# Altium schematic sheet Overvoltage_Protection.SchDoc(OVP)
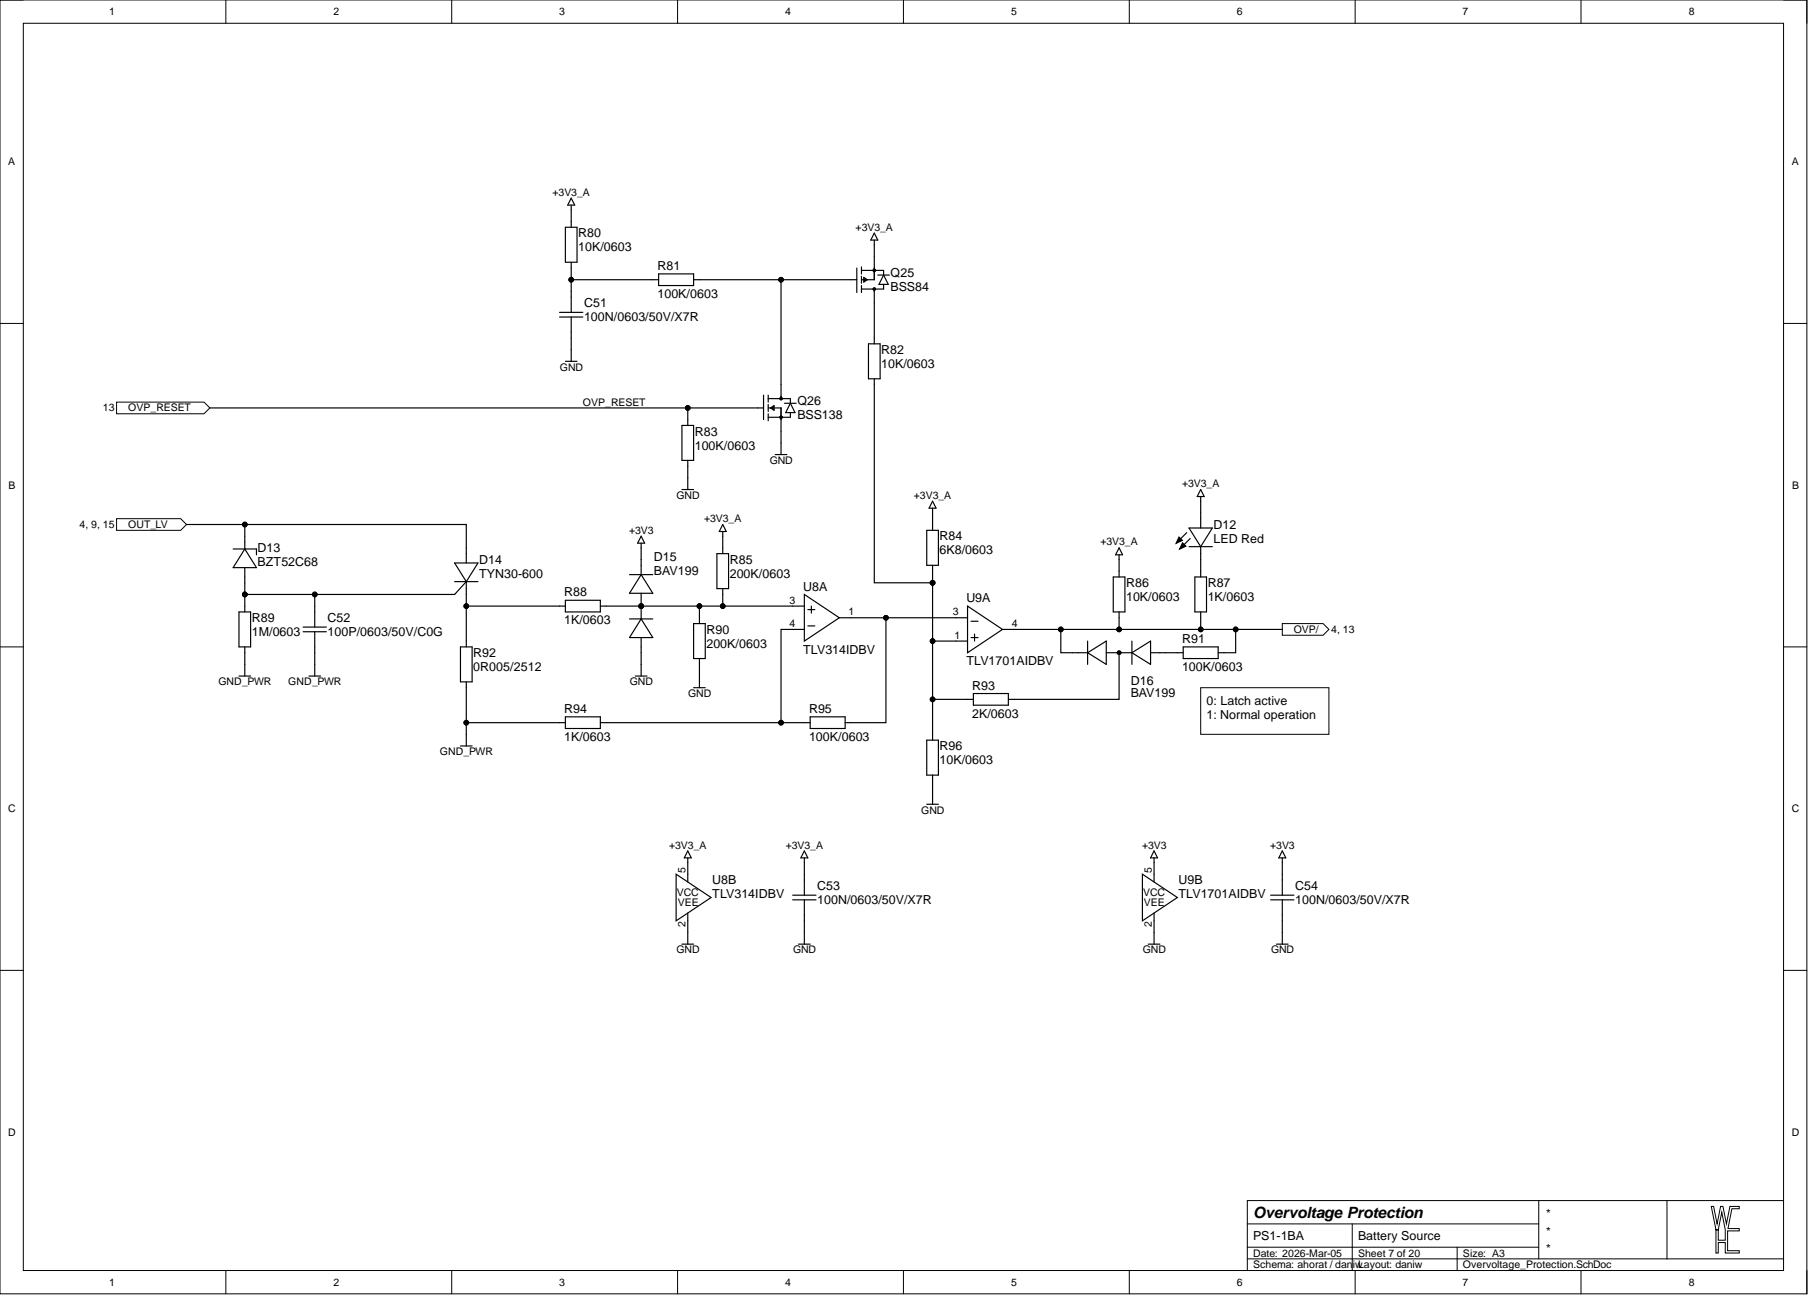
[diagram p0: Overvoltage_Protection.SchDoc]

## Parts
C51  100N/0603/50V/X7R  [CAP0603_X7R]
C52  100P/0603/50V/C0G  [CAP0603_C0G]
C53  100N/0603/50V/X7R  [CAP0603_X7R]
C54  100N/0603/50V/X7R  [CAP0603_X7R]
D12  LED Red  value Red  [LED0805_RED]
D13  BZT52C68  [SOD3718X125]
D14  TYN30-600  [TO220-3]
D15  BAV199  [SOT2395P280X125-3-SE]
D16  BAV199  [SOT2395P280X125-3-SE]
Q25  BSS84  [SOT2395P280X125-3-SE]
Q26  BSS138  [SOT2395P280X125-3-SE]
R80  10K/0603  [R0603-0.40-WW]
R81  100K/0603  [R0603-0.40-WW]
R82  10K/0603  [R0603-0.40-WW]
R83  100K/0603  [R0603-0.40-WW]
R84  6K8/0603  [R0603-0.40-WW]
R85  200K/0603  [R0603-0.40-WW]
R86  10K/0603  [R0603-0.40-WW]
R87  1K/0603  [R0603-0.40-WW]
R88  1K/0603  [R0603-0.40-WW]
R89  1M/0603  [R0603-0.40-WW]
R90  200K/0603  [R0603-0.40-WW]
R91  100K/0603  [R0603-0.40-WW]
R92  0R005/2512  [R2512-0.65-WW]
R93  2K/0603  [R0603-0.40-WW]
R94  1K/0603  [R0603-0.40-WW]
R95  100K/0603  [R0603-0.40-WW]
R96  10K/0603  [R0603-0.40-WW]
U8  TLV314IDBV  [SOT2395P280X125-5-NW]  (parts A,B)
U9  TLV1701AIDBV  [SOT2395P280X125-5-NW]  (parts A,B)

## Ports
OUT_LV, OVP/, OVP_RESET

## Nets
+3V3: C54.1 D15.2 U9.5
+3V3_A: C53.1 D12.2 Q25.2 R80.1 R84.1 R85.1 R86.2 U8.5
GND: C51.2 C53.2 C54.2 D15.1 Q26.2 R83.2 R90.2 R96.2 U8.2 U9.2
GND_PWR: C52.2 R89.2 R92.2 R94.2
OUT_LV: D13.1 D14.2 ; port OUT_LV
OVP/: D16.2 R86.1 R87.1 R91.2 U9.4 ; port OVP/
OVP_RESET: Q26.1 R83.1 ; port OVP_RESET
NetC51_1: C51.1 R80.2 R81.1
NetC52_1: C52.1 D13.2 D14.3 R89.1
NetD12_1: D12.1 R87.2
NetD14_1: D14.1 R88.1 R92.1
NetD15_3: D15.3 R85.2 R88.2 R90.1 U8.3
NetD16_1: D16.1 R91.1
NetD16_3: D16.3 R93.2
NetQ25_1: Q25.1 Q26.3 R81.2
NetQ25_3: Q25.3 R82.1
NetR82_2: R82.2 R84.2 R93.1 R96.1 U9.1
NetR94_1: R94.1 R95.2 U8.4
NetR95_1: R95.1 U8.1 U9.3

## Library data of the parts
C51 C53 C54 = 100N/0603/50V/X7R: CAP SM 0603 100nF 50V X7R; Manufacturer: GENERIC; Manufacturer 1: YAGEO; Manufacturer Part Number 1: CC0603KPX7R9BB104; Absolute Value: 1E-7; Database Table Name: C$; Eurocircuits GPN: GPC0603104; Component Kind: Standard; HelpURL: https://www.yageo.com/upload/media/product/app/datasheet/mlcc/upy-gphc_x7r_6_3v-to-250v.pdf; JLC Type: Basic; Manufacturer 2: YAGEO; Manufacturer Part Number 2: CC0603MRX7R9BB104; Manufacturer Part Number 3: CC0603KRX7R9BB104; Package: 0603; Part Number: 100N/0603/50V/X7R; Supplier 1: Digi-Key; Supplier 2: Digi-Key; Supplier 3: LCSC; Supplier Part Number 1: 311-3567-1-ND; Supplier Part Number 2: 311-4110-1-ND; Supplier Part Number 3: C14663; Value: 100nF; Library Name: daniw_altium_lib.DbLib
C52 = 100P/0603/50V/C0G: CAP SM 0603 100pF 50V C0G; Manufacturer: GENERIC; Manufacturer 1: YAGEO; Manufacturer Part Number 1: CC0603JRNPO9BN101; Absolute Value: 1E-10; Database Table Name: C$; Eurocircuits GPN: GPC0603101-C0G; Component Kind: Standard; HelpURL: https://www.yageo.com/upload/media/product/productsearch/datasheet/mlcc/UPY-GP_NP0_16V-to-50V_18.pdf; JLC Type: Basic; Manufacturer 2: YAGEO; Manufacturer Part Number 2: CC0603KRNPO9BN101; Manufacturer Part Number 3: CL10C101JB8NNNC; Package: 0603; Part Number: 100P/0603/50V/C0G; Supplier 1: Digi-Key; Supplier 2: Digi-Key; Supplier 3: LCSC; Supplier Part Number 1: 311-1069-1-ND; Supplier Part Number 2: 311-3600-1-ND; Supplier Part Number 3: C14858; Value: 100pF; Library Name: daniw_altium_lib.DbLib
D12 = LED Red 0805: Generic LED Red 0805; Manufacturer 1: Würth; Manufacturer Part Number 1: 150080RS75000; Database Table Name: D$; Absolute Value: Red; Component Kind: Standard; HelpURL: https://www.we-online.com/katalog/datasheet/150060RS75000.pdf; JLC Type: Basic; Manufacturer: GENERIC; Manufacturer 3: Foshan NationStar Optoelectronics; Manufacturer Part Number 3: NCD0805R1; Package: 805; Part Number: LED Red 0805; Supplier 1: Digikey; Supplier 3: LCSC; Supplier Part Number 1: 732-4984-1-ND; Supplier Part Number 3: C84256; Library Name: daniw_altium_lib.DbLib
D13 = BZT52C68: DIODE ZENER 68V 350MW SOD123; Manufacturer: Nexperia USA Inc.; Manufacturer Part Number 1: BZT52-C68J; Database Table Name: D$; Absolute Value: 68 V; Component Kind: Standard; HelpURL: https://assets.nexperia.com/documents/data-sheet/BZT52_SER.pdf; JLC Type: Extended; Manufacturer 1: Nexperia USA Inc.; Manufacturer 2: Panjit International Inc.; Manufacturer 3: JUXING; Manufacturer Part Number 2: BZT52-C68_R1_00001; Manufacturer Part Number 3: BZT52C68V; Package: SOD-123; Part Number: BZT52C68; Supplier 1: Digikey; Supplier 2: Digikey; Supplier 3: LCSC; Supplier Part Number 1: 1727-8144-1-ND; Supplier Part Number 2: 3757-BZT52-C68_R1_00001CT-ND; Supplier Part Number 3: C5365518; Value: BZT52C68; Library Name: daniw_altium_lib.DbLib
D14 = TYN30-600: Planar passivated Silicon Controlled Rectifier (SCR) in a TO220 plastic package, 600V, 30A; Manufacturer: WeEn Semiconductors; Manufacturer Part Number 1: TYN30-600TFQ; Database Table Name: D$; Value: TYN30-600TFQ; Component Kind: Standard; HelpURL: https://www.ween-semi.com/datasheet/TYN30-600TF.pdf; Manufacturer 1: WeEn Semiconductors; Package: TO-220; Part Number: TYN30-600; Supplier 1: Digikey; Supplier Part Number 1: 1740-TYN30-600TFQ-ND; Library Name: daniw_altium_lib.DbLib
D15 D16 = BAV199: Silicon Low Leakage Diode 85V; Manufacturer: Infineon Technologies; Manufacturer Part Number 1: BAV199E6433HTMA1; Database Table Name: D$; Absolute Value: 85V; Component Kind: Standard; HelpURL: https://www.infineon.com/dgdl/bav199series.pdf?folderId=db3a30431400ef6801141c748874044e&fileId=db3a30431400ef6801141cba733104e5; Manufacturer 1: Infineon Technologies; Manufacturer 3: LRC; Manufacturer Part Number 3: LBAV199LT1G; Package: SOT23; Part Number: BAV199; Supplier 1: Digikey; Supplier 3: LCSC; Supplier Part Number 1: BAV199E6433HTMA1CT-ND; Supplier Part Number 3: C12740; Value: BAV199; Library Name: daniw_altium_lib.DbLib
Q25 = BSS84: 50V P-Channel MOSFET; Manufacturer: GENERIC; Manufacturer 1: Good-Ark Semiconductor; Database Table Name: T$; Component Kind: Standard; HelpURL: https://goodarksemi.com/docs/datasheets/mosfets/BSS84.pdf; JLC Type: Extended; Manufacturer 2: LRC; Manufacturer 3: Jiangsu Changjing Electronics Technology Co., Ltd.; Manufacturer Part Number 1: BSS84; Manufacturer Part Number 2: LBSS84LT1G; Manufacturer Part Number 3: BSS84; Package: SOT23; Part Number: BSS84; Supplier 1: Digi-Key; Supplier 2: LCSC; Supplier 3: LCSC; Supplier Part Number 1: 4786-BSS84CT-ND; Supplier Part Number 2: C8492; Supplier Part Number 3: C114481; Library Name: daniw_altium_lib.DbLib
Q26 = BSS138: N-Kanal 50 V 220mA (Ta) 350mW (Ta) Oberflächenmontage SOT-23; Manufacturer: GENERIC; Manufacturer 1: ANBON SEMICONDUCTOR (INT'L) LIMITED; Database Table Name: T$; Component Kind: Standard; HelpURL: https://mm.digikey.com/Volume0/opasdata/d220001/medias/docus/3037/BSS138.pdf; JLC Type: Extended; Manufacturer 2: FOSAN; Manufacturer 3: FOSAN; Manufacturer Part Number 1: BSS138; Manufacturer Part Number 2: BSS138; Manufacturer Part Number 3: BSS138; Package: SOT23; Part Number: BSS138; Supplier 1: Digi-Key; Supplier 2: LCSC; Supplier 3: LCSC; Supplier Part Number 1: 4530-BSS138CT-ND; Supplier Part Number 2: C2926186; Supplier Part Number 3: C2926186; Library Name: daniw_altium_lib.DbLib
R80 R82 R86 R96 = 10K/0603: RES SM 0603 10K; Manufacturer: GENERIC; Manufacturer 1: YAGEO; Manufacturer Part Number 1: RC0603FR-1310KL; Absolute Value: 10000; Database Table Name: R$; Eurocircuits GPN: GPR060310K; Component Kind: Standard; HelpURL: https://www.yageogroup.com/content/datasheet/asset/file/PYU-RC_GROUP_51_ROHS_L; JLC Type: Basic; Manufacturer 2: YAGEO; Manufacturer 3: UNI-ROYAL(Uniroyal Elec); Manufacturer Part Number 2: RC0603FR-1010KL; Manufacturer Part Number 3: 0603WAF1002T5E; Package: 0603; Part Number: 10K/0603; Supplier 1: Digi-Key; Supplier 2: Digi-Key; Supplier 3: LCSC; Supplier Part Number 1: 13-RC0603FR-1310KLCT-ND; Supplier Part Number 2: 13-RC0603FR-1010KLCT-ND; Supplier Part Number 3: C25804; Value: 10K; Library Name: daniw_altium_lib.DbLib
R81 R83 R91 R95 = 100K/0603: RES SM 0603 100K; Manufacturer: GENERIC; Manufacturer 1: YAGEO; Manufacturer Part Number 1: RC0603FR-13100KL; Absolute Value: 100000; Database Table Name: R$; Eurocircuits GPN: GPR0603100K; Component Kind: Standard; HelpURL: https://www.yageogroup.com/content/datasheet/asset/file/PYU-RC_GROUP_51_ROHS_L; JLC Type: Basic; Manufacturer 2: YAGEO; Manufacturer 3: UNI-ROYAL(Uniroyal Elec); Manufacturer Part Number 2: RC0603FR-10100KL; Manufacturer Part Number 3: 0603WAF1003T5E; Package: 0603; Part Number: 100K/0603; Supplier 1: Digi-Key; Supplier 2: Digi-Key; Supplier 3: LCSC; Supplier Part Number 1: 13-RC0603FR-13100KLCT-ND; Supplier Part Number 2: 13-RC0603FR-10100KLCT-ND; Supplier Part Number 3: C25803; Value: 100K; Library Name: daniw_altium_lib.DbLib
R84 = 6K8/0603: RES SM 0603 6K8; Manufacturer: GENERIC; Manufacturer 1: YAGEO; Manufacturer Part Number 1: RC0603FR-136K8L; Absolute Value: 6800; Database Table Name: R$; Eurocircuits GPN: GPR06036K8; Component Kind: Standard; HelpURL: https://www.yageogroup.com/content/datasheet/asset/file/PYU-RC_GROUP_51_ROHS_L; JLC Type: Basic; Manufacturer 2: YAGEO; Manufacturer 3: UNI-ROYAL; Manufacturer Part Number 2: RC0603FR-106K8L; Manufacturer Part Number 3: 0603WAF6801T5E; Package: 0603; Part Number: 6K8/0603; Supplier 1: Digi-Key; Supplier 2: Digi-Key; Supplier 3: LCSC; Supplier Part Number 1: 13-RC0603FR-136K8LCT-ND; Supplier Part Number 2: 13-RC0603FR-106K8LCT-ND; Supplier Part Number 3: C23212; Value: 6K8; Library Name: daniw_altium_lib.DbLib
R85 R90 = 200K/0603: RES SM 0603 200K; Manufacturer: GENERIC; Manufacturer 1: YAGEO; Manufacturer Part Number 1: RC0603FR-13200KL; Absolute Value: 200000; Database Table Name: R$; Eurocircuits GPN: GPR0603200K; Component Kind: Standard; HelpURL: https://www.yageogroup.com/content/datasheet/asset/file/PYU-RC_GROUP_51_ROHS_L; JLC Type: Basic; Manufacturer 2: YAGEO; Manufacturer 3: UNI-ROYAL(Uniroyal Elec); Manufacturer Part Number 2: RC0603FR-10200KL; Manufacturer Part Number 3: 0603WAF2003T5E; Package: 0603; Part Number: 200K/0603; Supplier 1: Digi-Key; Supplier 2: Digi-Key; Supplier 3: LCSC; Supplier Part Number 1: 13-RC0603FR-13200KLCT-ND; Supplier Part Number 2: 13-RC0603FR-10200KLCT-ND; Supplier Part Number 3: C25811; Value: 200K; Library Name: daniw_altium_lib.DbLib
R87 R88 R94 = 1K/0603: RES SM 0603 1K; Manufacturer: GENERIC; Manufacturer 1: YAGEO; Manufacturer Part Number 1: RC0603FR-131KL; Absolute Value: 1000; Database Table Name: R$; Eurocircuits GPN: GPR06031K; Component Kind: Standard; HelpURL: https://www.yageogroup.com/content/datasheet/asset/file/PYU-RC_GROUP_51_ROHS_L; JLC Type: Basic; Manufacturer 2: YAGEO; Manufacturer 3: UNI-ROYAL(Uniroyal Elec); Manufacturer Part Number 2: RC0603FR-101KL; Manufacturer Part Number 3: 0603WAF1001T5E; Package: 0603; Part Number: 1K/0603; Supplier 1: Digi-Key; Supplier 2: Digi-Key; Supplier 3: LCSC; Supplier Part Number 1: 13-RC0603FR-131KLCT-ND; Supplier Part Number 2: 13-RC0603FR-101KLCT-ND; Supplier Part Number 3: C21190; Value: 1K; Library Name: daniw_altium_lib.DbLib
R89 = 1M/0603: RES SM 0603 1M; Manufacturer: GENERIC; Manufacturer 1: YAGEO; Manufacturer Part Number 1: RC0603FR-131ML; Absolute Value: 1000000; Database Table Name: R$; Eurocircuits GPN: GPR06031M; Component Kind: Standard; HelpURL: https://www.yageogroup.com/content/datasheet/asset/file/PYU-RC_GROUP_51_ROHS_L; JLC Type: Basic; Manufacturer 2: YAGEO; Manufacturer 3: UNI-ROYAL(Uniroyal Elec); Manufacturer Part Number 2: RC0603FR-101ML; Manufacturer Part Number 3: 0603WAF1004T5E; Package: 0603; Part Number: 1M/0603; Supplier 1: Digi-Key; Supplier 2: Digi-Key; Supplier 3: LCSC; Supplier Part Number 1: 13-RC0603FR-131MLCT-ND; Supplier Part Number 2: 13-RC0603FR-101MLCT-ND; Supplier Part Number 3: C22935; Value: 1M; Library Name: daniw_altium_lib.DbLib
R92 = 0R005/2512: RES SM 2512 0R005; Manufacturer: GENERIC; Manufacturer 1: Ohmite; Manufacturer Part Number 1: MCS3264R005FER; Absolute Value: 0.005; Database Table Name: R$; Component Kind: Standard; HelpURL: https://www.ohmite.com/assets/docs/res_mcs.pdf?r=false; Manufacturer 3: prosemi; Manufacturer Part Number 3: LMP25MF2P0R005; Package: 2512; Part Number: 0R005/2512; Supplier 1: Digi-Key; Supplier 3: LCSC; Supplier Part Number 1: MCS3264R005FERCT-ND; Supplier Part Number 3: C2937402; Value: 0R005; Library Name: daniw_altium_lib.DbLib
R93 = 2K/0603: RES SM 0603 2K; Manufacturer: GENERIC; Manufacturer 1: YAGEO; Manufacturer Part Number 1: RC0603FR-132KL; Absolute Value: 2000; Database Table Name: R$; Eurocircuits GPN: GPR06032K; Component Kind: Standard; HelpURL: https://www.yageogroup.com/content/datasheet/asset/file/PYU-RC_GROUP_51_ROHS_L; JLC Type: Basic; Manufacturer 2: YAGEO; Manufacturer 3: UNI-ROYAL(Uniroyal Elec); Manufacturer Part Number 2: RC0603FR-102KL; Manufacturer Part Number 3: 0603WAF2001T5E; Package: 0603; Part Number: 2K/0603; Supplier 1: Digi-Key; Supplier 2: Digi-Key; Supplier 3: LCSC; Supplier Part Number 1: 13-RC0603FR-132KLCT-ND; Supplier Part Number 2: 13-RC0603FR-102KLCT-ND; Supplier Part Number 3: C22975; Value: 2K; Library Name: daniw_altium_lib.DbLib
U8 = TLV314 SOT23: TLV314, 3-MHz, Low-Power, Internal EMI Filter, RRIO, Operational Amplifier; Manufacturer: Texas Instruments; Manufacturer Part Number 1: TLV314IDBVR; Database Table Name: Integrated$; Component Kind: Standard; HelpURL: https://www.ti.com/lit/ds/symlink/tlv4314.pdf; Manufacturer 1: Texas Instruments; Manufacturer 2: Texas Instruments; Manufacturer 3: Texas Instruments; Manufacturer Part Number 2: TLV314IDBVT; Manufacturer Part Number 3: TLV314IDBVR; Package: SOT23-5; Part Number: TLV314 SOT23; Supplier 1: Digi-Key; Supplier 2: Digi-Key; Supplier 3: LCSC; Supplier Part Number 1: 296-46275-1-ND; Supplier Part Number 2: 296-44110-2-ND; Supplier Part Number 3: C133032; Library Name: daniw_altium_lib.DbLib
U9 = TLV1701 SOT23: TLV1701 2.2-V to 36-V, microPower Comparato; Manufacturer: Texas Instruments; Manufacturer Part Number 1: TLV1701AIDBVR; Database Table Name: Integrated$; Component Kind: Standard; HelpURL: https://www.ti.com/lit/ds/symlink/tlv1701.pdf; Manufacturer 1: Texas Instruments; Manufacturer 2: Texas Instruments; Manufacturer 3: Texas Instruments; Manufacturer Part Number 2: TLV1701QDBVRQ1; Manufacturer Part Number 3: TLV1701AIDBVR; Package: SOT23-5; Part Number: TLV1701 SOT23; Supplier 1: Digi-Key; Supplier 2: Digi-Key; Supplier 3: LCSC; Supplier Part Number 1: 296-40599-1-ND; Supplier Part Number 2: 296-47458-1-ND; Supplier Part Number 3: C130035; Library Name: daniw_altium_lib.DbLib
